# Altium schematic sheet Sheet1.SchDoc(Sheet1)
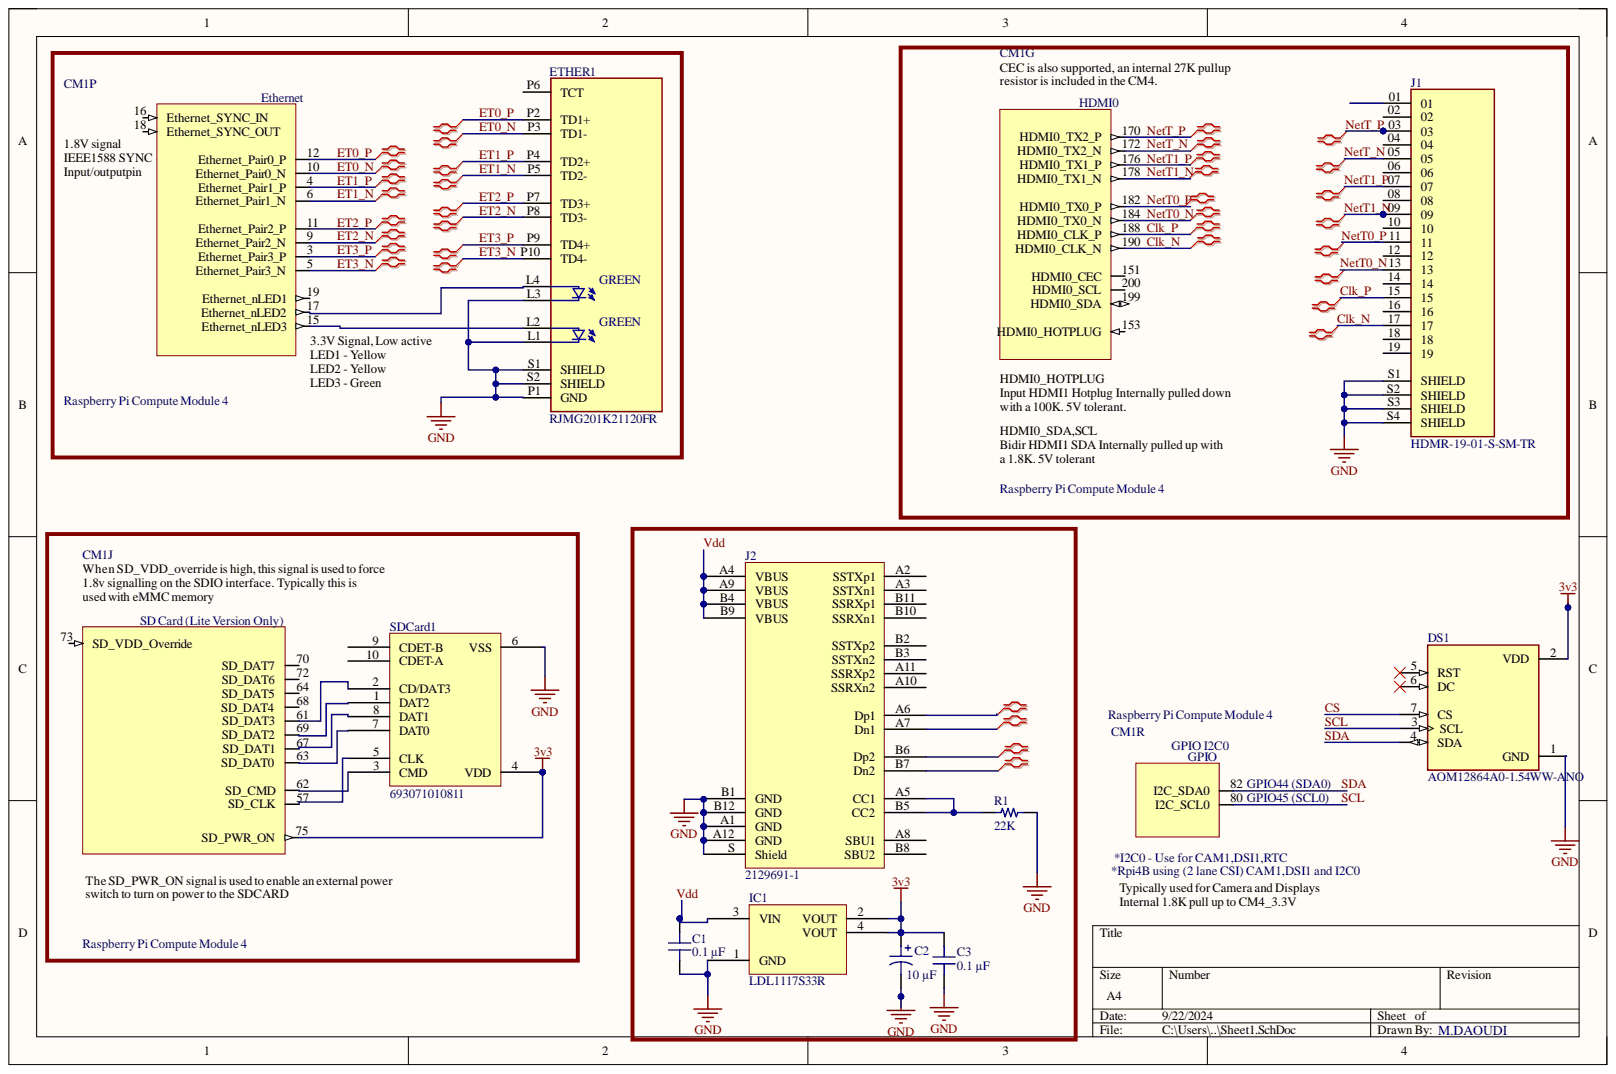
[diagram p0: Sheet1.SchDoc]

## Parts
C1  0.1 µF  [CAP 0402_1005]
C2  10 µF  [CAP ALSMD 4.3MM H5.4MM]
C3  0.1 µF  [CAP 0402_1005]
CM1  Raspberry Pi Compute Module 4  [CM4-F40C-100DS-0.4v_1.5mm_0mm]  (no BOM)  (parts G,J,P,R)
DS1  AOM12864A0-1.54WW-ANO  [LCD_AOM12864A0-1.54WW-ANO]
ETHER1  RJMG201K21120FR  [AMPHENOL_RJMG201K21120FR]
IC1  LDL1117S33R  [ST SOT-223]
J1  HDMR-19-01-S-SM-TR  [SAMTEC_HDMR-19-01-S-SM-TR]
J2  2129691-1  [TE USB-C 2129691-1]
R1  22K  [RES 0201_0603]
SDCard1  693071010811  [WURTH 693071010811]

## Nets
3v3: C2.1 C3.2 CM1.75(SD_PWR_ON) DS1.2(VDD) IC1.2(VOUT) IC1.4(VOUT) SDCard1.4(VDD)
GND: C1.1 C2.2 C3.1 DS1.1(GND) ETHER1.L1 ETHER1.L3 ETHER1.P1(GND) ETHER1.S1(SHIELD) ETHER1.S2(SHIELD) IC1.1(GND) J1.S1(SHIELD) J1.S2(SHIELD) J1.S3(SHIELD) J1.S4(SHIELD) J2.A1(GND) J2.A12(GND) J2.B1(GND) J2.B12(GND) J2.S(Shield) R1.1 SDCard1.6(VSS)
Clk_N: CM1.190(HDMI0_CLK_N) J1.17
Clk_P: CM1.188(HDMI0_CLK_P) J1.15
CS: DS1.7(CS)
ET0_N: CM1.10(Ethernet_Pair0_N) ETHER1.P3(TD1-)
ET0_P: CM1.12(Ethernet_Pair0_P) ETHER1.P2(TD1+)
ET1_N: CM1.6(Ethernet_Pair1_N) ETHER1.P5(TD2-)
ET1_P: CM1.4(Ethernet_Pair1_P) ETHER1.P4(TD2+)
ET2_N: CM1.9(Ethernet_Pair2_N) ETHER1.P8(TD3-)
ET2_P: CM1.11(Ethernet_Pair2_P) ETHER1.P7(TD3+)
ET3_N: CM1.5(Ethernet_Pair3_N) ETHER1.P10(TD4-)
ET3_P: CM1.3(Ethernet_Pair3_P) ETHER1.P9(TD4+)
NetT0_N: CM1.184(HDMI0_TX0_N) J1.13
NetT0_P: CM1.182(HDMI0_TX0_P) J1.11
NetT1_N: CM1.178(HDMI0_TX1_N) J1.09
NetT1_P: CM1.176(HDMI0_TX1_P) J1.07
NetT_N: CM1.172(HDMI0_TX2_N) J1.05
NetT_P: CM1.170(HDMI0_TX2_P) J1.03
SCL: CM1.80 DS1.3(SCL)
SDA: CM1.82 DS1.4(SDA)
Vdd: J2.A4(VBUS) J2.A9(VBUS) J2.B4(VBUS) J2.B9(VBUS)
NetC1_2: C1.2 IC1.3(VIN)
NetCM1_15: CM1.15(Ethernet_nLED3) ETHER1.L2
NetCM1_17: CM1.17(Ethernet_nLED2) ETHER1.L4
NetCM1_57: CM1.57(SD_CLK) SDCard1.5(CLK)
NetCM1_61: CM1.61(SD_DAT3) SDCard1.2(CD/DAT3)
NetCM1_62: CM1.62(SD_CMD) SDCard1.3(CMD)
NetCM1_63: CM1.63(SD_DAT0) SDCard1.7(DAT0)
NetCM1_67: CM1.67(SD_DAT1) SDCard1.8(DAT1)
NetCM1_69: CM1.69(SD_DAT2) SDCard1.1(DAT2)
NetJ2_A5: J2.A5(CC1) J2.B5(CC2) R1.2

Not connected: CM1 16(Ethernet_SYNC_IN) 18(Ethernet_SYNC_OUT) 19(Ethernet_nLED1) 64(SD_DAT5) 68(SD_DAT4) 70(SD_DAT7) 72(SD_DAT6) 73(SD_VDD_Override) 151(HDMI0_CEC) 153 199(HDMI0_SDA) 200(HDMI0_SCL); DS1 5(RST) 6(DC); ETHER1 P6(TCT); J1 01 02 04 06 08 10 12 14 16 18 19; J2 A2(SSTXp1) A3(SSTXn1) A6(Dp1) A7(Dn1) A8(SBU1) A10(SSRXn2) A11(SSRXp2) B2(SSTXp2) B3(SSTXn2) B6(Dp2) B7(Dn2) B8(SBU2) B10(SSRXn1) B11(SSRXp1); SDCard1 9(CDET-B) 10(CDET-A)

## Library data of the parts
C1 C3 = CL05B104KP5VPNC: CAP CER 100NF 10V X7R 0402; manufacturer: Samsung Electro-Mechanics; Database Table Name: Capacitors - Ceramic - 0402; Tolerance: ±10%; Applications: Automotive; Capacitance: 0.1 µF; Component Kind: Standard; componentlink1description: Datasheet; componentlink1url: https://mm.digikey.com/Volume0/opasdata/d220001/medias/docus/5458/CL05B104KP5VPNC_Spec.pdf; componentlink2description: DigiKey Link; componentlink2url: https://www.digikey.com/en/products/detail/samsung-electro-mechanics/CL05B104KP5VPNC/20498482; Device Package: 0402 (1005 Metric); lastupdated: 2/9/2024 8:03:17 PM; Library Name: Celestial Altium Library - altium_library.DbLib; Lifecycle Status: Active; Minimum Order: 1; Mounting Type: Surface Mount, MLCC; Operating Temperature: -55°C ~ 125°C; Package / Case: 0402 (1005 Metric); packaging: TapeAndReel; Part Number: CL05B104KP5VPNC; partid: 56690; price: 0.01; Ratings: AEC-Q200; series: CL; Size / Dimension: 0.039" L x 0.020" W (1.00mm x 0.50mm); Supplier 1: DigiKey; Supplier Part Number 1: 1276-CL05B104KP5VPNCCT-ND; Temperature Coefficient: X7R; Thickness (Max): 0.022" (0.55mm); Value: 0.1 µF; Voltage - Rated: 10V
C2 = 865090140001: CAP ALUM 10UF 20% 6.3V SMD; manufacturer: Würth Elektronik; Database Table Name: Capacitors - Aluminum - Electrolytic SMT; Lifetime @ Temp.: 1000 Hrs @ 85°C; Polarization: Polar; Ripple Current @ High Frequency: 22.5 mA @ 100 kHz; Ripple Current @ Low Frequency: 15 mA @ 120 Hz; Surface Mount Land Size: 0.169" L x 0.169" W (4.30mm x 4.30mm); Applications: General Purpose; Capacitance: 10 µF; Component Kind: Standard; componentlink1description: Datasheet; componentlink1url: https://katalog.we-online.de/pbs/datasheet/865090140001.pdf; componentlink2description: DigiKey Link; componentlink2url: https://www.digikey.com/en/products/detail/würth-elektronik/865090140001/5727980; Device Package: Radial, Can - SMD; Height - Seated (Max): 0.217" (5.50mm); lastupdated: 6/3/2024 7:52:57 PM; Library Name: Celestial Altium Library - altium_library.DbLib; Lifecycle Status: Active; Minimum Order: 1; Mounting Type: Surface Mount; Operating Temperature: -40°C ~ 85°C; Package / Case: Radial, Can - SMD; packaging: TapeAndReel; Part Number: 865090140001; Part Status: Active; partid: 7396; price: 0.10; series: WCAP-ASLU; Size / Dimension: 0.157" Dia (4.00mm); Supplier 1: DigiKey; Supplier Part Number 1: 732-8385-1-ND; Tolerance: ±20%; value: 10 µF; Voltage - Rated: 6.3 V
CM1 = CM4: Raspberry Pi Compute Module 4 by Amornthep Phunsin; Library Name: CM4.SchLib
DS1 = AOM12864A0-1.54WW-ANO: Non-Touch Graphic LCD Display Module - White OLED SPI 1.54 (39.12mm) 128 x 64; MF: Orient Display; Availability: In Stock; Check_prices: https://www.snapeda.com/parts/AOM12864A0-1.54WW-ANO/Orient+Display/view-part/?ref=eda; Component Kind: Standard; Library Name: AOM12864A0-1.54WW-ANO.IntLib; MP: AOM12864A0-1.54WW-ANO; Package: None; Price: None; Purchase-URL: https://pricing.snapeda.com/search/part/AOM12864A0-1.54WW-ANO/?ref=eda; SnapEDA_Link: https://www.snapeda.com/parts/AOM12864A0-1.54WW-ANO/Orient+Display/view-part/?ref=snap
ETHER1 = RJMG201K21120FR: RJMG, Input output Connectors, 1x1 1000 base-T LED; MF: Amphenol ICC (Commercial Products); Availability: In Stock; Check_prices: https://www.snapeda.com/parts/RJMG201K21120FR/Amphenol/view-part/?ref=eda; Component Kind: Standard; Library Name: RJMG201K21120FR.IntLib; MP: RJMG201K21120FR; Package: None; Price: None; Purchase-URL: https://pricing.snapeda.com/search/part/RJMG201K21120FR/?ref=eda; SnapEDA_Link: https://www.snapeda.com/parts/RJMG201K21120FR/Amphenol/view-part/?ref=snap
IC1 = LDL1117S33R: IC REG LINEAR 3.3V 1.2A SOT223; Manufacturer: STMicroelectronics; Current - Quiescent (Iq): 500 µA; Database Table Name: Voltage Regulators - Linear; Number of Regulators: 1; Output Configuration: Positive; Output Type: Fixed; Protection Features: Over Current, Over Temperature, Under Voltage Lockout (UVLO); PSRR: 87dB ~ 65dB (120Hz ~ 100kHz); Voltage - Input (Max): 18V; Voltage Dropout (Max): 0.6V @ 1.2A; Component Kind: Standard; ComponentLink1Description: Datasheet; ComponentLink1URL: http://www.st.com/content/ccc/resource/technical/document/datasheet/group3/0e/5a/00/ca/10/1a/4f/a5/DM00366442/files/DM00366442.pdf/jcr:content/translations/en.DM00366442.pdf; ComponentLink2Description: DigiKey Link; ComponentLink2URL: https://www.digikey.com/en/products/detail/stmicroelectronics/LDL1117S33R/7102071; Current - Output: 1.2A; LastUpdated: 6/3/2024 8:25:59 PM; Library Name: Celestial Altium Library - altium_library.DbLib; Lifecycle Status: Active; Minimum Order: 1; Mounting Type: Surface Mount; Operating Temperature: -40°C ~ 125°C (TJ); Package / Case: TO-261-4, TO-261AA; Packaging: TapeAndReel; Part Number: LDL1117S33R; Part Status: Active; Price: 0.31; Series: -; Supplier 1: DigiKey; Supplier Device Package: SOT-223; Supplier Part Number 1: 497-17239-1-ND; Voltage - Output (Min/Fixed): 3.3V
J1 = HDMR-19-01-S-SM-TR: HDMI Receptacle Connector 19 Position Surface Mount, Right Angle; Through Hole; MF: Samtec Inc.; Availability: Bad; Check_prices: https://www.snapeda.com/parts/HDMR-19-01-S-SM-TR/Samtec%20Inc./view-part/2748128/?ref=eda; Component Kind: Standard; Library Name: HDMR-19-01-S-SM-TR.IntLib; MP: HDMR-19-01-S-SM-TR; Package: None; Price: None; SnapEDA_Link: https://www.snapeda.com/parts/HDMR-19-01-S-SM-TR/Samtec%20Inc./view-part/2748128/?ref=snap
J2 = 2129691-1: CONN RCPT USB3.1 TYPEC 24POS SMD; Database Table Name: Connectors - USB DVI HDMI; Component Kind: Standard; Library Name: Celestial Altium Library - altium_library.DbLib; Part Number: 2129691-1
R1 = 7-2176074-4: RES SMD 33.2KOHM 0.5% 1/32W 0201; Database Table Name: Resistors - Surface Mount - 0201; Component Kind: Standard; Library Name: Celestial Altium Library - altium_library.DbLib; Part Number: 7-2176074-4
SDCard1 = 693071010811: CONN MICRO SD CARD PUSH-PUSH R/A; Manufacturer: Würth Elektronik; Card Type: Secure Digital - microSD™; Connector Type: Connector and Ejector; Database Table Name: Connectors - Memory - Card Sockets; Insertion, Removal Method: Push In, Push Out; Number of Positions: 9 (8 + 1); Component Kind: Standard; ComponentLink1Description: Datasheet; ComponentLink1URL: https://katalog.we-online.de/em/datasheet/693071010811.pdf; ComponentLink2Description: DigiKey Link; ComponentLink2URL: https://www.digikey.com/en/products/detail/würth-elektronik/693071010811/3124583; Contact Finish: Gold; Features: Switch; Height Above Board: 0.072" (1.83mm); LastUpdated: 21/06/2022 14:51:31; Library Name: Celestial Altium Library - altium_library.DbLib; Lifecycle Status: Active; Minimum Order: 1; Mounting Feature: Normal, Standard - Top; Mounting Type: Surface Mount, Right Angle; Packaging: TapeAndReel; Part Number: 693071010811; Part Status: Active; Price: 1.95; Series: WR-CRD; Supplier 1: DigiKey; Supplier Part Number 1: 732-3819-1-ND
